# Altium schematic sheet 猫眼主板 V1.0.SchDoc
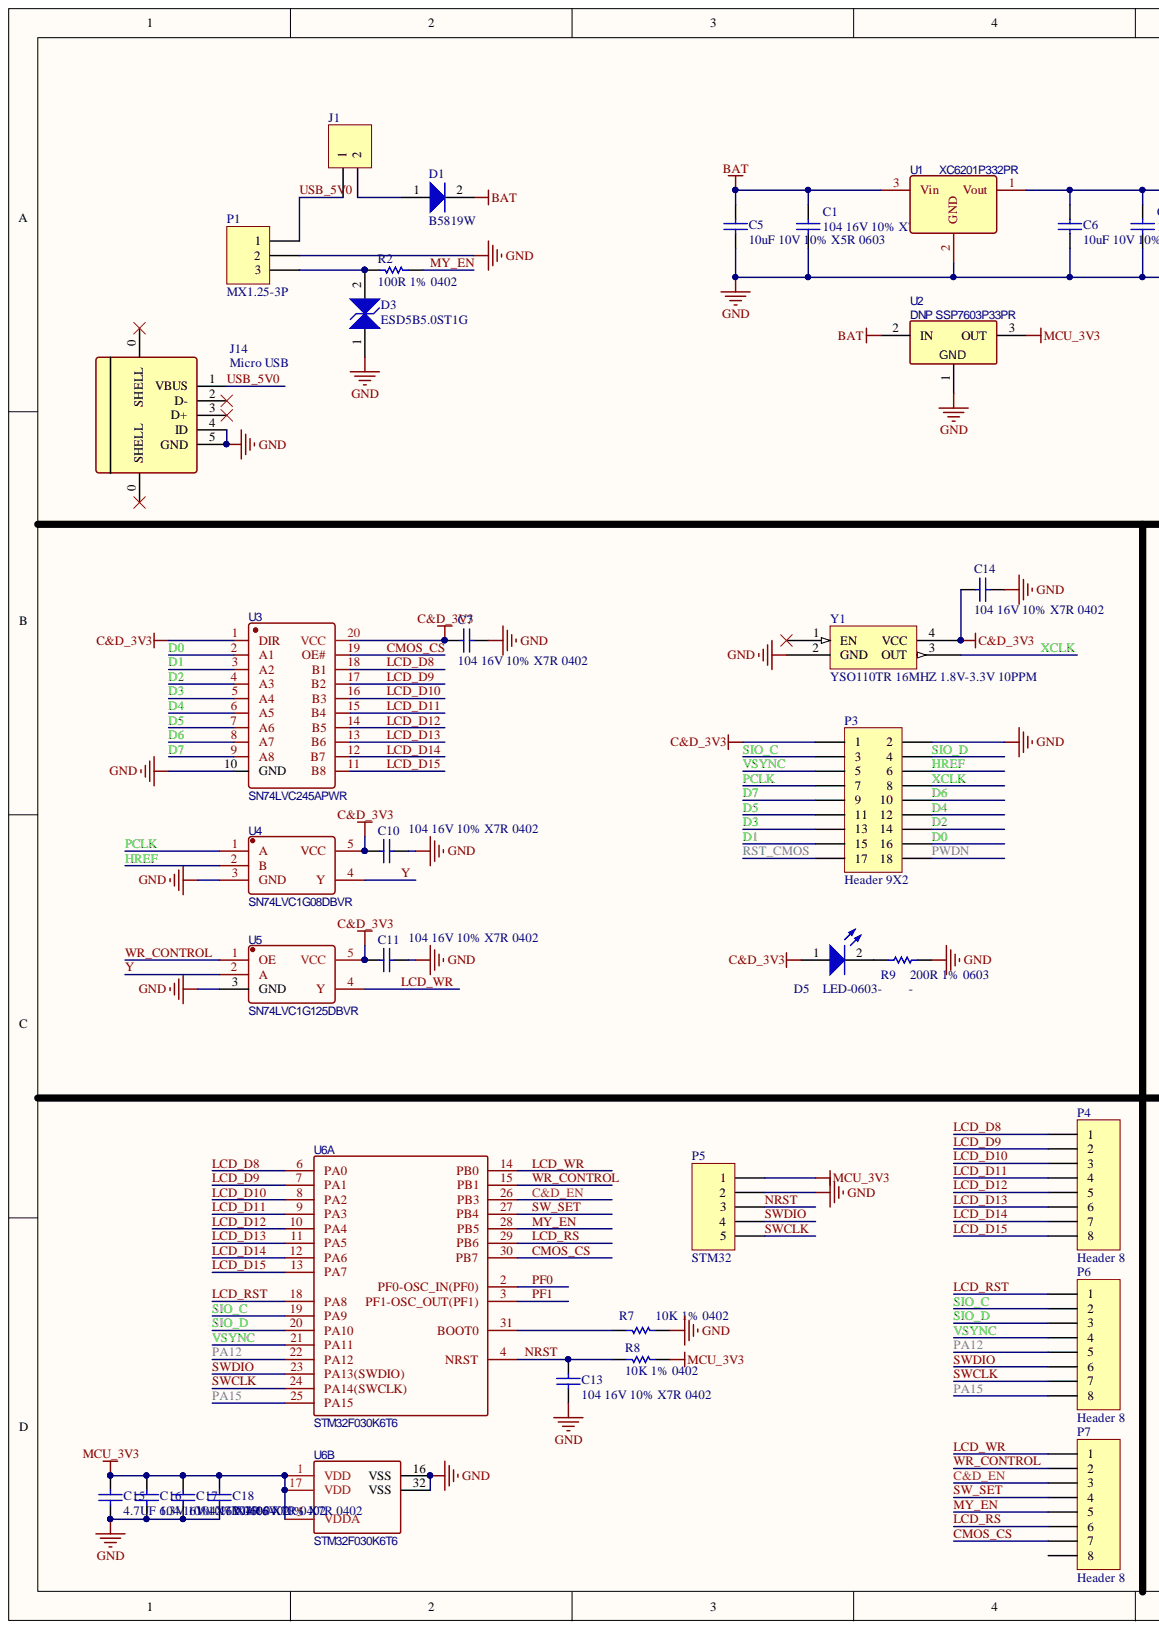
[diagram p0: 猫眼主板 V1.0.SchDoc, left]
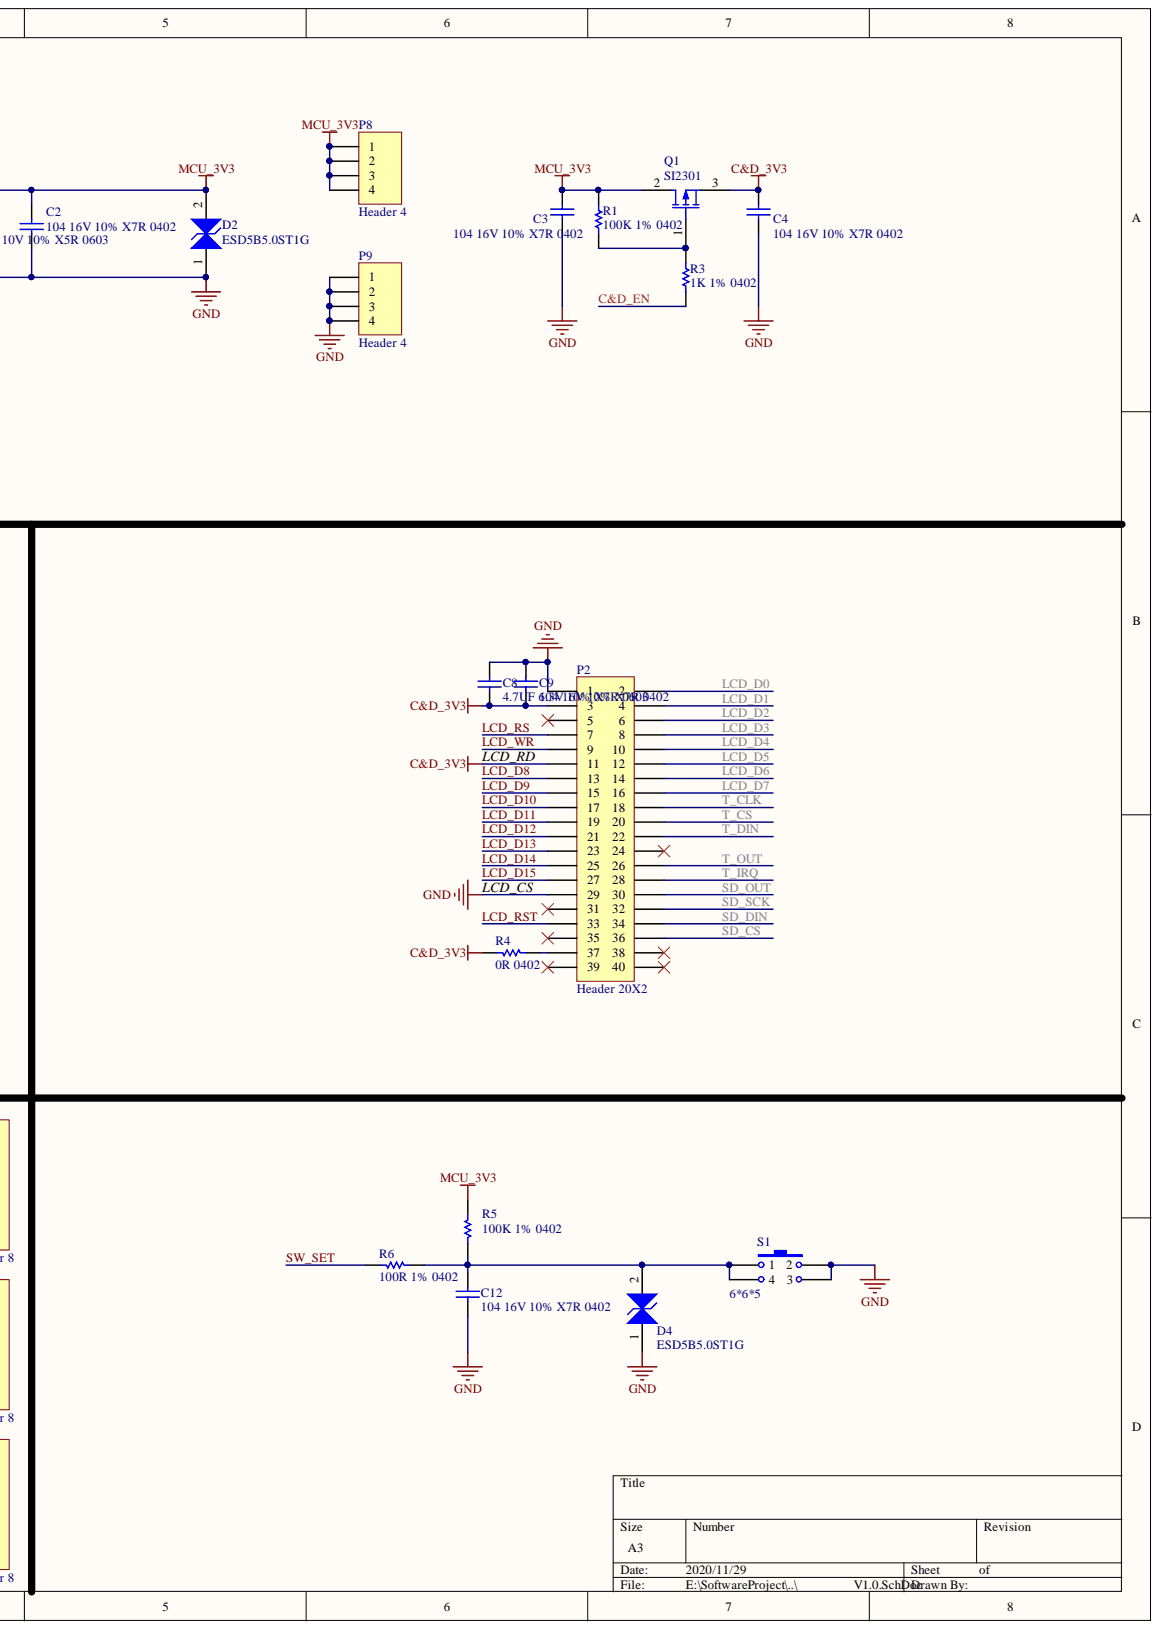
[diagram p1: 猫眼主板 V1.0.SchDoc, right]

## Parts
C1  104 16V 10% X7R 0402  [C0402]
C2  104 16V 10% X7R 0402  [C0402]
C3  104 16V 10% X7R 0402  [C0402]
C4  104 16V 10% X7R 0402  [C0402]
C5  10uF 10V 10% X5R 0603  [C0603]
C6  10uF 10V 10% X5R 0603  [C0603]
C7  104 16V 10% X7R 0402  [C0402]
C8  4.7UF 6.3V 10% X7R 0603  [C0603]
C9  104 16V 10% X7R 0402  [C0402]
C10  104 16V 10% X7R 0402  [C0402]
C11  104 16V 10% X7R 0402  [C0402]
C12  104 16V 10% X7R 0402  [C0402]
C13  104 16V 10% X7R 0402  [C0402]
C14  104 16V 10% X7R 0402  [C0402]
C15  4.7UF 6.3V 10% X7R 0603  [C0603]
C16  104 16V 10% X7R 0402  [C0402]
C17  104 16V 10% X7R 0402  [C0402]
C18  104 16V 10% X7R 0402  [C0402]
D1  B5819W  [SOD-123]
D2  ESD5B5.0ST1G  [SOD-523]
D3  ESD5B5.0ST1G  [SOD-523]
D4  ESD5B5.0ST1G  [SOD-523]
D5  LED-0603-白色-正  [LED-0603]  (value not drawn)
J1  跳线  [HDR1X2]  (value not drawn)
J14  Micro USB座  [MICRO-USB-16]  (value not drawn)
P1  MX1.25-3P 卧贴 插座  [MX 1.25-WS-3P]  (value not drawn)
P2  Header 20X2  [HDR2X20]
P3  Header 9X2  [HDR2X9]
P4  Header 8  [HDR1X8]
P5  STM32烧写口  [HDR1X5]  (value not drawn)
P6  Header 8  [HDR1X8]
P7  Header 8  [HDR1X8]
P8  Header 4  [HDR1X4]
P9  Header 4  [HDR1X4]
Q1  SI2301  [SOT-23]
R1  100K 1% 0402  [R0402]
R2  100R 1% 0402  [R0402]
R3  1K 1% 0402  [R0402]
R4  0R 0402  [R0402]
R5  100K 1% 0402  [R0402]
R6  100R 1% 0402  [R0402]
R7  10K 1% 0402  [R0402]
R8  10K 1% 0402  [R0402]
R9  200R 1% 0603  [R0603]
S1  6*6*5 贴片轻触开关  [SW-6*6-SMD]  (value not drawn)
U1  XC6201P332PR  [SOT-89]
U2  DNP SSP7603P33PR  [SOT-89]
U3  SN74LVC245APWR  [TSSOP-20]
U4  SN74LVC1G08DBVR  [SOT-23-5]
U5  SN74LVC1G125DBVR  [SOT-23-5]
U6  STM32F030K6T6  [TQFP32 7x7_M]  (parts A,B)
Y1  YSO110TR 16MHZ 1.8V-3.3V 10PPM  [XTAL-3225]

## Nets
BAT: C1.2 C5.1 D1.2 U1.3 U2.2
C&D_3V3: C4.1 C7.1 C8.1 C9.1 C10.2 C11.2 C14.1 D5.1 P2.3 P2.11 P3.1 Q1.3 R4.1 U3.1(DIR) U3.20(VCC) U4.5(VCC) U5.5(VCC) Y1.4(VCC)
GND: C1.1 C2.1 C3.2 C4.2 C5.2 C6.2 C7.2 C8.2 C9.2 C10.1 C11.1 C12.2 C13.1 C14.2 C15.1 C16.1 C17.1 C18.1 D2.1 D3.1 D4.1 J14.4(ID) J14.5(GND) P1.2 P2.1 P2.29 P3.2 P5.2 P9.1 P9.2 P9.3 P9.4 R7.2 R9.1 S1.2 S1.3 U1.2 U2.1 U3.10(GND) U4.3(GND) U5.3(GND) U6.16(VSS) U6.32(VSS) Y1.2(GND)
MCU_3V3: C2.2 C3.1 C6.1 C15.2 C16.2 C17.2 C18.2 D2.2 P5.1 P8.1 P8.2 P8.3 P8.4 Q1.2 R1.2 R5.1 R8.2 U1.1 U2.3 U6.1(VDD) U6.5(VDDA) U6.17(VDD)
C&D_EN: P7.3 R3.1 U6.26(PB3)
CMOS_CS: P7.7 U3.19(OE#) U6.30(PB7)
D0: P3.16 U3.2(A1)
D1: P3.15 U3.3(A2)
D2: P3.14 U3.4(A3)
D3: P3.13 U3.5(A4)
D4: P3.12 U3.6(A5)
D5: P3.11 U3.7(A6)
D6: P3.10 U3.8(A7)
D7: P3.9 U3.9(A8)
HREF: P3.6 U4.2(B)
LCD_D0: P2.2
LCD_D1: P2.4
LCD_D2: P2.6
LCD_D3: P2.8
LCD_D4: P2.10
LCD_D5: P2.12
LCD_D6: P2.14
LCD_D7: P2.16
LCD_D8: P2.13 P4.1 U3.18(B1) U6.6(PA0)
LCD_D9: P2.15 P4.2 U3.17(B2) U6.7(PA1)
LCD_D10: P2.17 P4.3 U3.16(B3) U6.8(PA2)
LCD_D11: P2.19 P4.4 U3.15(B4) U6.9(PA3)
LCD_D12: P2.21 P4.5 U3.14(B5) U6.10(PA4)
LCD_D13: P2.23 P4.6 U3.13(B6) U6.11(PA5)
LCD_D14: P2.25 P4.7 U3.12(B7) U6.12(PA6)
LCD_D15: P2.27 P4.8 U3.11(B8) U6.13(PA7)
LCD_RS: P2.7 P7.6 U6.29(PB6)
LCD_RST: P2.33 P6.1 U6.18(PA8)
LCD_WR: P2.9 P7.1 U5.4(Y) U6.14(PB0)
MY_EN: P7.5 R2.2 U6.28(PB5)
NRST: C13.2 P5.3 R8.1 U6.4(NRST)
PA12: P6.5 U6.22(PA12)
PA15: P6.8 U6.25(PA15)
PCLK: P3.7 U4.1(A)
PF0: U6.2(PF0-OSC_IN(PF0))
PF1: U6.3(PF1-OSC_OUT(PF1))
PWDN: P3.18
RST_CMOS: P3.17
SD_CS: P2.36
SD_DIN: P2.34
SD_OUT: P2.30
SD_SCK: P2.32
SIO_C: P3.3 P6.2 U6.19(PA9)
SIO_D: P3.4 P6.3 U6.20(PA10)
SW_SET: P7.4 R6.1 U6.27(PB4)
SWCLK: P5.5 P6.7 U6.24(PA14(SWCLK))
SWDIO: P5.4 P6.6 U6.23(PA13(SWDIO))
T_CLK: P2.18
T_CS: P2.20
T_DIN: P2.22
T_IRQ: P2.28
T_OUT: P2.26
USB_5V0: J1.1 J14.1(VBUS) P1.1
VSYNC: P3.5 P6.4 U6.21(PA11)
WR_CONTROL: P7.2 U5.1(OE) U6.15(PB1)
XCLK: P3.8 Y1.3(OUT)
Y: U4.4(Y) U5.2(A)
NetC12_1: C12.1 D4.2 R5.2 R6.2 S1.1 S1.4
NetD1_1: D1.1 J1.2
NetD3_2: D3.2 P1.3 R2.1
NetD5_2: D5.2 R9.2
NetP2_37: P2.37 R4.2
NetQ1_1: Q1.1 R1.1 R3.2
NetR7_1: R7.1 U6.31(BOOT0)

Not connected: J14 0(SHELL) 2(D-) 3(D+); P2 5 24 31 35 38 39 40; P7 8; Y1 1(EN)

## Library data of the parts
C1-C18 = CapN: CAP; 制造商: SAMSUNG; Component Kind: Standard; Library Name: CommonSchLib.SchLib
D1 = 1N4007: Code_JEDEC: DO-214-AB; Component Kind: Standard; LatestRevisionDate: 17-Jul-2002; LatestRevisionNote: Re-released for DXP Platform.; Library Name: CommonSchLib.SchLib; PackageDescription: DO-214-AB/SMC; 2 C-Bend Leads; Body 7.9 x 5.9 mm, inc. leads (LxW); PackageReference: SMC; PackageVersion: Sep-1996; Published: 8-Jun-2000; Publisher: Altium Limited
D2-D4 = BTVS: 双向ESD; Component Kind: Standard; Library Name: CommonSchLib.SchLib
D5 = LED: Component Kind: Standard; Description: LED; Library Name: CommonSchLib.SchLib
J1 = Header 2: Header, 2-Pin; Component Kind: Standard; LatestRevisionDate: 17-Jul-2002; LatestRevisionNote: Re-released for DXP Platform.; Library Name: Miscellaneous Connectors.IntLib; Signal Integrity: Connector; Publisher: Altium Limited
J14 = MICRO-USB: Component Kind: Standard; Library Name: ConnectorSchLib.SchLib
P1 = Header 3: Header, 3-Pin; Component Kind: Standard; LatestRevisionDate: 17-Jul-2002; LatestRevisionNote: Re-released for DXP Platform.; Library Name: Miscellaneous Connectors.IntLib; Signal Integrity: Connector; Publisher: Altium Limited
P2 = Header 20X2: Header, 20-Pin, Dual row; Component Kind: Standard; LatestRevisionDate: 17-Jul-2002; LatestRevisionNote: Re-released for DXP Platform.; Library Name: ConnectorSchLib.SchLib; Signal Integrity: Connector; Publisher: Altium Limited
P3 = Header 9X2: Header, 10-Pin, Dual row; : 摄像头; Component Kind: Standard; LatestRevisionDate: 17-Jul-2002; LatestRevisionNote: Re-released for DXP Platform.; Library Name: ConnectorSchLib.SchLib; Signal Integrity: Connector; Publisher: Altium Limited
P4 P6 P7 = Header 8: Header, 8-Pin; Component Kind: Standard; LatestRevisionDate: 17-Jul-2002; LatestRevisionNote: Re-released for DXP Platform.; Library Name: ConnectorSchLib.SchLib; Signal Integrity: Connector; Publisher: Altium Limited
P5 = Header 5: Header, 5-Pin; Component Kind: Standard; Library Name: ConnectorSchLib.SchLib; Signal Integrity: Connector
P8 P9 = Header 4: Header, 4-Pin; Component Kind: Standard; LatestRevisionDate: 17-Jul-2002; LatestRevisionNote: Re-released for DXP Platform.; Library Name: ConnectorSchLib.SchLib; Signal Integrity: Connector; Publisher: Altium Limited
Q1 = MOSFET-P: Component Kind: Standard; Library Name: CommonSchLib.SchLib; Simulation: PMOS
R1-R9 = Res1: Resistor; Component Kind: Standard; Library Name: Miscellaneous Devices.IntLib; Signal Integrity: Res; Simulation: RESISTOR
S1 = KEY1_2: 按钮; Designer: Anson Chen; : 设置键; Component Kind: Standard; Creation Time: 2012.03.25; Library Name: CommonSchLib.SchLib
U1 = XC6201P332PR: BOM_Manufacturer: TOREX; SMT Type: Extend; BOM_LCSC Assembly: Yes; BOM_Manufacturer Part: XC6201P332PR; BOM_Supplier: LCSC; BOM_Supplier Part: C18754; Component Kind: Standard; image: //image.lceda.cn/szlcsc/C18754.jpg; Library Name: PowerSchLib.SchLib; spicePre: U
U2 = HT7333-1: BOM_Manufacturer: HOLTEK; SMT Type: Extend; BOM_LCSC Assembly: Yes; BOM_Manufacturer Part: HT7333-1; BOM_Supplier: LCSC; BOM_Supplier Part: C77777; Component Kind: Standard; Library Name: PowerSchLib.SchLib; spicePre: L
U3 = SN74LVC245APWR: BOM_Manufacturer: TI; SMT Type: Extend; BOM_LCSC Assembly: Yes; BOM_Manufacturer Part: SN74LVC245APWR; BOM_Supplier: LCSC; BOM_Supplier Part: C7848; Component Kind: Standard; image: //image.lceda.cn/szlcsc/C7848.jpg; Library Name: LogicSchLib.SchLib; spicePre: X; spiceSymbolName: SN74LVC245APWR
U4 = SN74LVC1G08DBVR: BOM_Manufacturer: TI; SMT Type: Extend; BOM_LCSC Assembly: Yes; BOM_Manufacturer Part: SN74LVC1G08DBVR; BOM_Supplier: LCSC; BOM_Supplier Part: C7666; Component Kind: Standard; image: //image.lceda.cn/szlcsc/C7666.jpg; Library Name: LogicSchLib.SchLib; spicePre: U; spiceSymbolName: SN74LVC1G08DBVR
U5 = SN74LVC1G125DBVR: BOM_Manufacturer: TI; SMT Type: Extend; BOM_LCSC Assembly: Yes; BOM_Manufacturer Part: SN74LVC1G125DBVR; BOM_Supplier: LCSC; BOM_Supplier Part: C23654; Component Kind: Standard; image: //image.lceda.cn/szlcsc/C23654.jpg; Library Name: LogicSchLib.SchLib; spicePre: X; spiceSymbolName: SN74LVC1G125DBVR
U6 = STM32F030K6T6: BOM_Manufacturer: ST; subpart_no: 1; tags: STM32; BOM_Manufacturer Part: STM32F030K6T6; BOM_Supplier: LCSC; BOM_Supplier Part: C46830; Component Kind: Standard; image: http://image.lceda.cn/szlcsc/C46830.jpg; Library Name: MicrocontrollerSchLib.SchLib; spicePre: U; spiceSymbolName: STM32F030K6T6; timeStamp: 1450544170
Y1 = XTALH: 有源晶振; Value: 50MHz; Component Kind: Standard; Library Name: CommonSchLib.SchLib
